# Altium schematic sheet [02] - IntelliModule Connector.SchDoc("[02] - IntelliModule Connector")
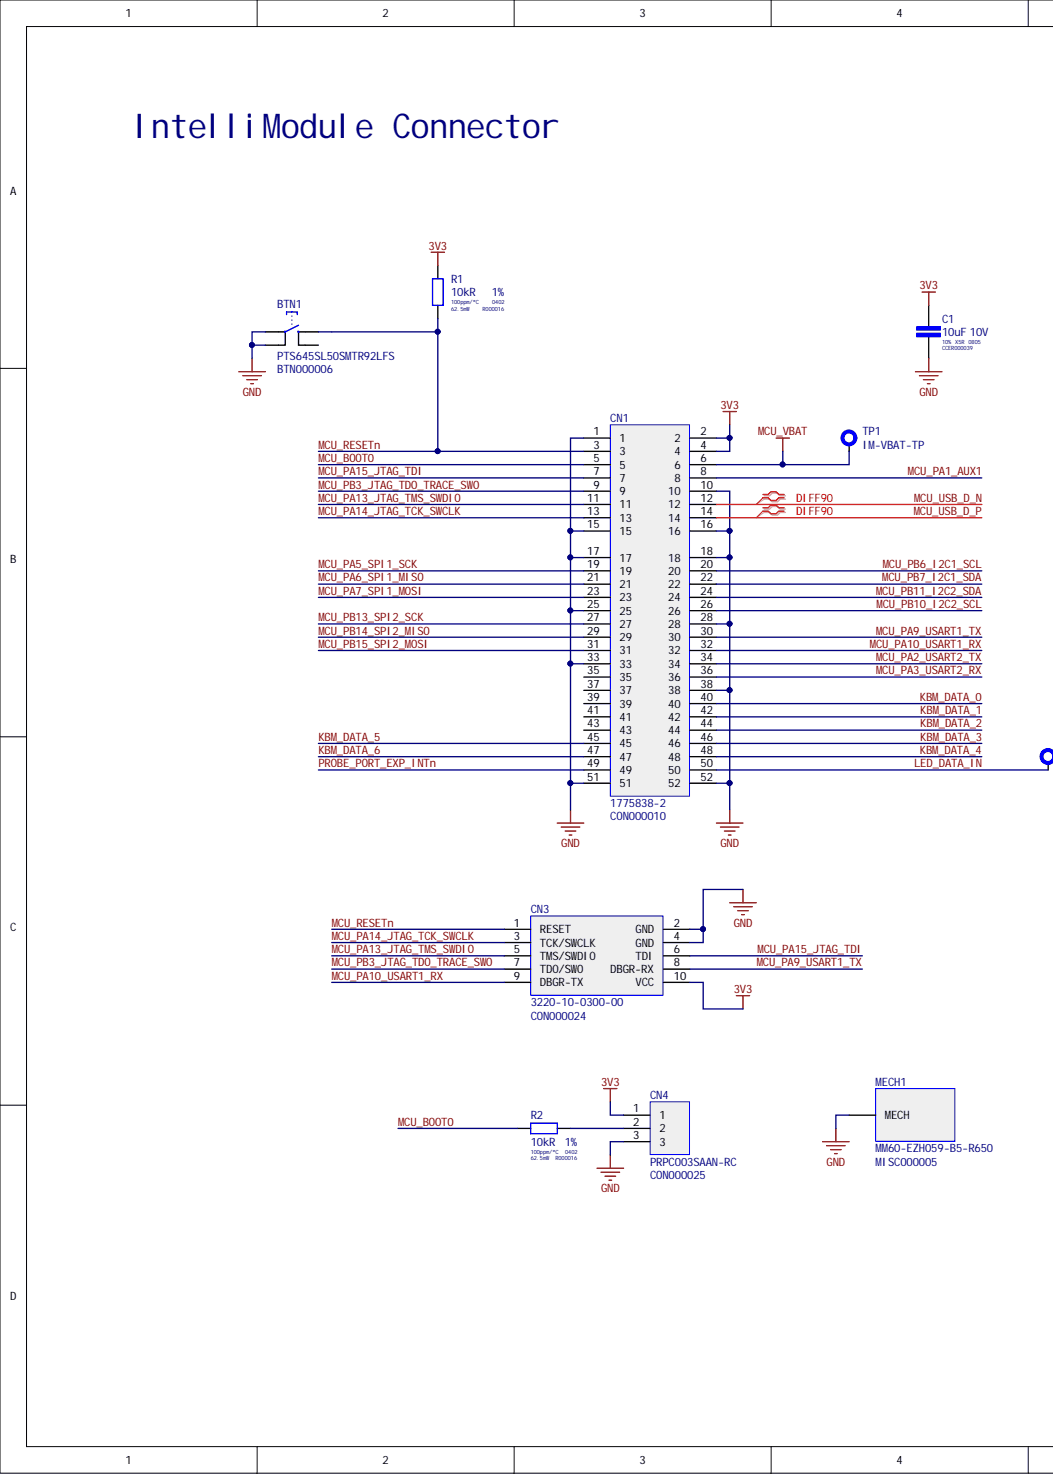
[diagram p0: [02] - IntelliModule Connector.SchDoc, left]
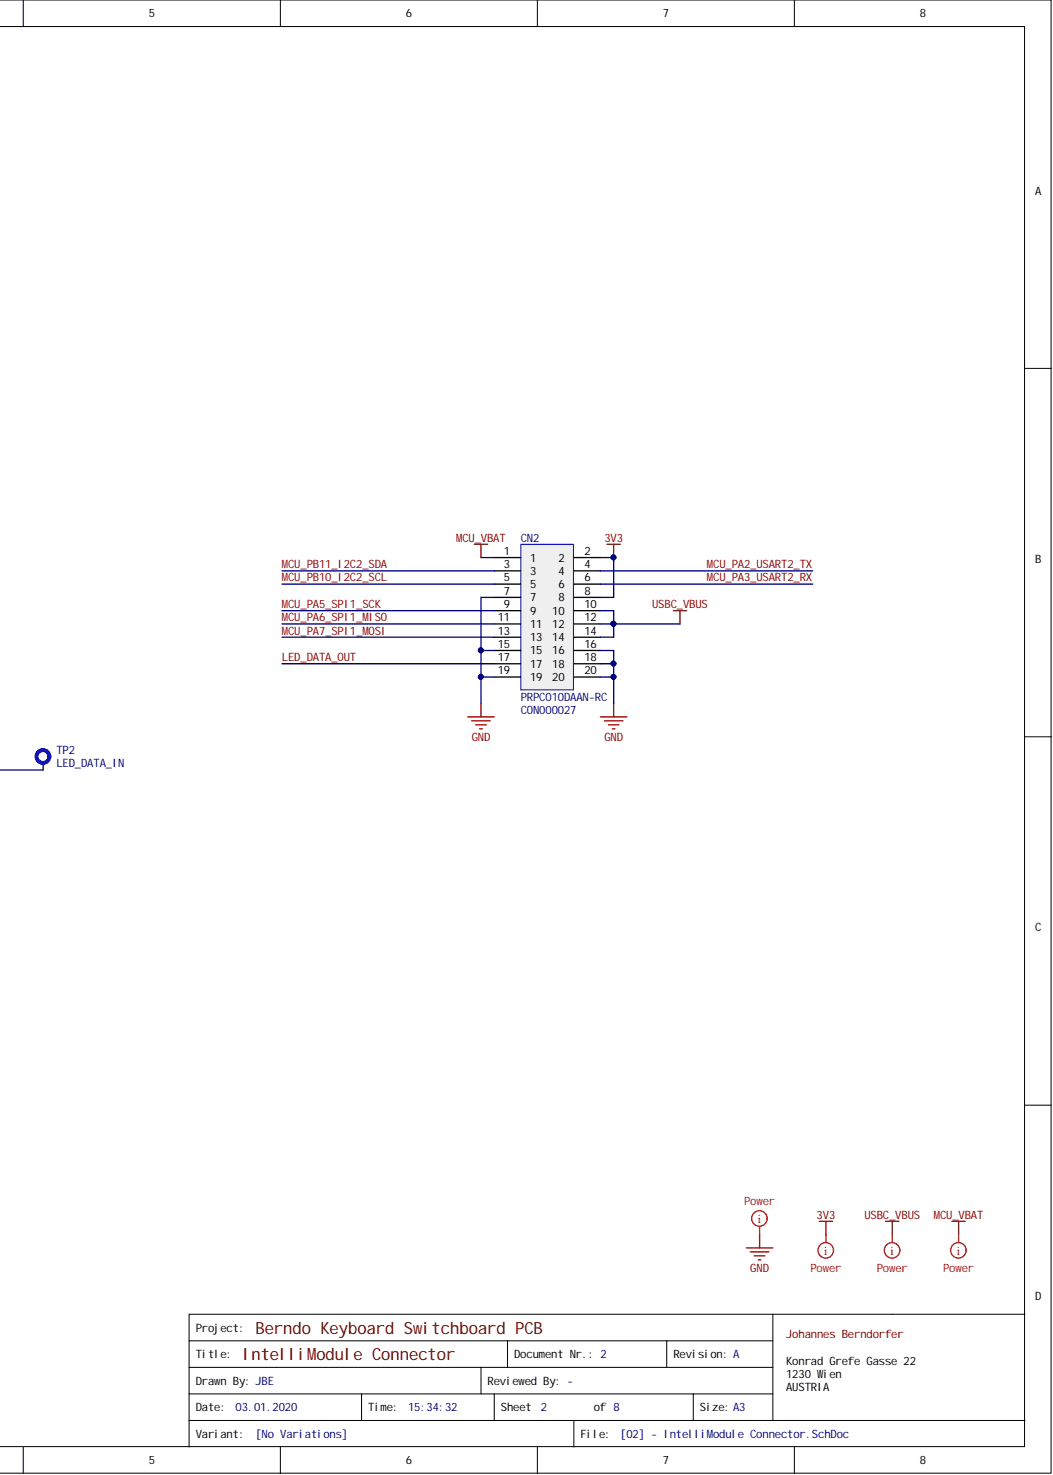
[diagram p1: [02] - IntelliModule Connector.SchDoc, right]

## Parts
BTN1  PTS645SL50SMTR92LFS  Internal Part Number: BTN000006  [BTN-SMD-PTS645-CK]
C1  10uF 10V  Voltage Rating: 10V  Tolerance: 10%  Internal Part Number: CCER000039  Dielectric: X5R  Case Code (Imperial): 0805  Capacitance: 10uF  [CAP-SMD-0805-1.35MM-IPC-MED]
CN1  1775838-2  [CON-SMD-PCIE-MINI-52POS-1775838-2-TE]
CN2  PRPC010DAAN-RC  [CON-THT-PINHEADER-2.54MM-20POS-2X10]
CN3  3220-10-0300-00  [CON-SMD-PINHEADER-SHIELDED-1.27MM-10POS-2X5-3220-10-0300-00-CNC-TECH]
CN4  PRPC003SAAN-RC  [CON-THT-PINHEADER-2.54MM-3POS-1X3]
MECH1  MM60-EZH059-B5-R650  Internal Part Number: MISC000005  [CON-SMD-PCIE-MINI-LATCH-0POS-MM60-EZH059-B5-R650-JAE]
R1  Temperature Coefficient: 100ppm/°C  Resistance: 10kR  Power Rating: 62.5mW  [RES-SMD-0402-0.4MM-IPC-MED]  (hidden comment: 10kR 1%)
R2  10kR 1%  Tolerance: 1%  Temperature Coefficient: 100ppm/°C  Resistance: 10kR  Power Rating: 62.5mW  Internal Part Number: R000016  Case Code (Imperial): 0402  [RES-SMD-0402-0.4MM-IPC-MED]
TP1  IM-VBAT-TP  [TP-SMD-CIRCULAR-1MM]
TP2  LED_DATA_IN  [TP-SMD-CIRCULAR-1MM]

## Nets
3V3: C1.1 CN1.2 CN1.4 CN2.2 CN2.8 CN3.10(VCC) CN4.1 R1.1
GND: BTN1.1 BTN1.2 C1.2 CN1.1 CN1.10 CN1.15 CN1.16 CN1.17 CN1.18 CN1.25 CN1.28 CN1.33 CN1.38 CN1.51 CN1.52 CN2.7 CN2.15 CN2.16 CN2.18 CN2.19 CN2.20 CN3.2(GND) CN3.4(GND) CN4.3 MECH1.0
MCU_VBAT: CN1.6 CN2.1 TP1.1
USBC_VBUS: CN2.10 CN2.12 CN2.14
KBM_DATA_0: CN1.40
KBM_DATA_1: CN1.42
KBM_DATA_2: CN1.44
KBM_DATA_3: CN1.46
KBM_DATA_4: CN1.48
KBM_DATA_5: CN1.45
KBM_DATA_6: CN1.47
LED_DATA_IN: CN1.50 TP2.1
LED_DATA_OUT: CN2.17
MCU_BOOT0: CN1.5 R2.1
MCU_PA1_AUX1: CN1.8
MCU_PA2_USART2_TX: CN1.34 CN2.4
MCU_PA3_USART2_RX: CN1.36 CN2.6
MCU_PA5_SPI1_SCK: CN1.19 CN2.9
MCU_PA6_SPI1_MISO: CN1.21 CN2.11
MCU_PA7_SPI1_MOSI: CN1.23 CN2.13
MCU_PA9_USART1_TX: CN1.30 CN3.8(DBGR-RX)
MCU_PA10_USART1_RX: CN1.32 CN3.9(DBGR-TX)
MCU_PA13_JTAG_TMS_SWDIO: CN1.11 CN3.5(TMS/SWDIO)
MCU_PA14_JTAG_TCK_SWCLK: CN1.13 CN3.3(TCK/SWCLK)
MCU_PA15_JTAG_TDI: CN1.7 CN3.6(TDI)
MCU_PB3_JTAG_TDO_TRACE_SWO: CN1.9 CN3.7(TDO/SWO)
MCU_PB6_I2C1_SCL: CN1.20
MCU_PB7_I2C1_SDA: CN1.22
MCU_PB10_I2C2_SCL: CN1.26 CN2.5
MCU_PB11_I2C2_SDA: CN1.24 CN2.3
MCU_PB13_SPI2_SCK: CN1.27
MCU_PB14_SPI2_MISO: CN1.29
MCU_PB15_SPI2_MOSI: CN1.31
MCU_RESETn: BTN1.3 CN1.3 CN3.1(RESET) R1.2
MCU_USB_D_N: CN1.12
MCU_USB_D_P: CN1.14
PROBE_PORT_EXP_INTn: CN1.49
NetCN4_2: CN4.2 R2.2

Not connected: BTN1 4; CN1 35 37 39 41 43

## Library data of the parts
BTN1 = PTS645SL50SMTR92LFS: BTN TACT SPST-NO 12V 50mA SMD BLACK; Manufacturer: ITT C&K; Throw Configuration: SPST; Switch Function: Off-Mom; Operating Force: 1.27N; Mechanical Life: 100000Cycles; Illumination Type: Non-Illuminated; Actuator Type: Standard; Actuator Material: Nylon,Polyamide; Voltage Rating (DC): 12V; Termination: Gull Wing; Supplier Part Number 1: CKN9088CT-ND; Supplier 1: Digi-Key; Stock 1: 127172; RoHS: Yes; REACH SVHC: No SVHC; Pricing 1: 1 = 0,31000, 10 = 0,30000, 25 = 0,29000, 50 = 0,27960, 100 = 0,26410, 250 = 0,24860, 500 = 0,23822 (USD); Packaging: Tape and Reel; Package Quantity: 1000; Orientation: Straight; Mount: Surface Mount; Min Operating Temperature: -20°C; Max Voltage Rating (DC): 12V; Max Operating Temperature: 60°C; Max Current Rating: 50mA; Manufacturer Part Number: PTS645SL50SMTR92LFS; Library Name: Altium_Lib_Standard.IntLib; Length: 6mm; Lead Free: Lead Free; Depth: 9mm; Current Rating: 50mA; Contact Plating: Silver; Contact Material: Bronze; ComponentLink6URL: https://datasheet.ciiva.com/46429/1839862-46429338.pdf?src-supplier=Element14; ComponentLink6Description: https://datasheet.ciiva.com/46429/1839862-46429338.pdf?src-supplier=Element14; ComponentLink5URL: https://datasheet.ciiva.com/46429/1839862-46429337.pdf?src-supplier=Element14; ComponentLink5Description: https://datasheet.ciiva.com/46429/1839862-46429337.pdf?src-supplier=Element14; ComponentLink4URL: https://datasheet.ciiva.com/14920/pts645-14920744.pdf?src-supplier=Digi-Key; ComponentLink4Description: https://datasheet.ciiva.com/14920/pts645-14920744.pdf?src-supplier=Digi-Key; ComponentLink3URL: https://datasheet.ciiva.com/14920/pts645-14920743.pdf?src-supplier=Digi-Key; ComponentLink3Description: https://datasheet.ciiva.com/14920/pts645-14920743.pdf?src-supplier=Digi-Key; ComponentLink2URL: https://datasheet.ciiva.com/14920/pts645-14920742.pdf?src-supplier=Digi-Key; ComponentLink2Description: https://datasheet.ciiva.com/14920/pts645-14920742.pdf?src-supplier=Digi-Key; ComponentLink1URL: https://datasheet.ciiva.com/26927/getdatasheetpartid-760859-26927133.pdf?src-supplier=Verical; ComponentLink1Description: https://datasheet.ciiva.com/26927/getdatasheetpartid-760859-26927133.pdf?src-supplier=Verical; Component Kind: Standard; Color: Black
C1 = CL21A106KPFNNNG: CAP CER 10U 10V X5R 10% SMD 0805 85C SAMSUNG; Width: 0.049inch; Voltage: 10V; Thickness: 0.053inch; Termination: Wraparound; Supplier Part Number 1: 1276-6456-1-ND; Supplier 1: Digi-Key; Stock 1: 884042; RoHS: Yes; Radiation Hardening: No; Pricing 1: 1=0,14000, 10=0,10000, 100=0,04690, 500=0,03290, 1000=0,02724 (USD); Packaging: Tape and Reel; Package Quantity: 3000; Mount: Surface Mount; Min Operating Temperature: -55°C; Max Operating Temperature: 85°C; Manufacturer Part Number 1: CL21A106KPFNNNG; Manufacturer 1: Samsung; Library Name: Altium_Lib_Standard.IntLib; Length: 2mm; Lead Free: Lead Free; Height: 1.25mm; Depth: 1.25mm; ComponentLink2URL: https://datasheet.ciiva.com/26936/getdatasheetpartid-640497-26936900.pdf?src-supplier=Verical; ComponentLink2Description: https://datasheet.ciiva.com/26936/getdatasheetpartid-640497-26936900.pdf?src-supplier=Verical; ComponentLink1URL: https://datasheet.ciiva.com/16428/cl21a106kpfnnng-16428896.pdf?src-supplier=Future+Electronics; ComponentLink1Description: https://datasheet.ciiva.com/16428/cl21a106kpfnnng-16428896.pdf?src-supplier=Future+Electronics; Component Kind: Standard; Case Code (Metric): 2012; Case/Package: 0805
CN1 = 1775838-2: CON MINI PCIE CARD EDGE 52POS SMD; Manufacturer 1: TE Connectivity AMP; Internal Part Number: CON000010; Stack Height: 5.6mm; Stackable: No; Row Spacing: 0.031inch; Pitch: 0.8mm; PCB Mount Retention: Without; PCB Mounting Orientation: Vertical; Number of Positions: 52; High Temperature Housing: Yes; Connector Type: Receptacle; Termination: Solder; Supplier Part Number 1: A123340CT-ND; Supplier 1: Digi-Key; Stock 1: 12692; RoHS: Yes; Pricing 1: 1=0,76000, 10=0,67100, 25=0,63160, 50=0,60520, 100=0,57880, 250=0,52620 (USD); Packaging: Tape and Reel; Package Quantity: 500; Orientation: Right Angle; Number of Rows: 2; Mount: Surface Mount; Manufacturer Part Number 1: 1775838-2; Library Name: Altium_Lib_Standard.IntLib; Lead Free: Lead Free; Housing Material: Thermoplastic; Housing Color: Black; Flammability Rating: UL94 V-0; ELV: Compliant; Contact Plating: Gold; Contact Material: Copper Alloy; ComponentLink3URL: https://datasheet.ciiva.com/10924/eng-ds-8-1773459-7-express-mini-card-qrg-1011-10924539.pdf?src-supplier=Avnet; ComponentLink3Description: https://datasheet.ciiva.com/10924/eng-ds-8-1773459-7-express-mini-card-qrg-1011-10924539.pdf?src-supplier=Avnet; ComponentLink2URL: https://datasheet.ciiva.com/48777/eng-ds-8-1773459-7-express-mini-card-qrg-1011-48777258.pdf?src-supplier=Onlinecomponents.com; ComponentLink2Description: https://datasheet.ciiva.com/48777/eng-ds-8-1773459-7-express-mini-card-qrg-1011-48777258.pdf?src-supplier=Onlinecomponents.com; ComponentLink1URL: https://datasheet.ciiva.com/16468/eng-ds-8-1773459-7-express-mini-card-qrg-1011-16468375.pdf?src-supplier=Avnet+Asia; ComponentLink1Description: https://datasheet.ciiva.com/16468/eng-ds-8-1773459-7-express-mini-card-qrg-1011-16468375.pdf?src-supplier=Avnet+Asia; Component Kind: Standard
CN2 = PRPC010DAAN-RC: CON PINHDR 2.54MM 2x10; Manufacturer: Sullins; Internal Part Number: CON000027; Row Spacing: 0.1inch; Number of Positions: 20; Connector Type: Male Pin; Termination: Solder; Supplier Part Number 1: S2011EC-10-ND; Supplier 1: Digi-Key; Stock 1: 2248; RoHS: Yes; Pricing 1: 1 = 0,50000, 10 = 0,46400, 25 = 0,41800, 50 = 0,37160, 100 = 0,35600, 250 = 0,32508, 500 = 0,30960 (USD); Pitch: 0.1inch; Packaging: Bulk; Package Quantity: 1; Number of Rows: 2; Mount: Through Hole; Manufacturer Part Number: PRPC010DAAN-RC; Library Name: Altium_Lib_Standard.IntLib; Contact Plating: Gold; Contact Mating Length: 0.23inch; ComponentLink1URL: https://datasheet.ciiva.com/741/xrxczzzdxxn-rc-11636-b-741275.pdf?src-supplier=Digi-Key; ComponentLink1Description: https://datasheet.ciiva.com/741/xrxczzzdxxn-rc-11636-b-741275.pdf?src-supplier=Digi-Key; Component Kind: Standard; Color: Black
CN3 = 3220-10-0300-00: CON PINHDR SMD 1.27MM SHIELDED KEYED CNC TECH 10POS; Internal Part Number: CON000024; Row Spacing: 0.05inch; Number of Positions: 10; Connector Type: Male Pin; Termination: Solder; Supplier Part Number 1: 1175-1629-ND; Supplier 1: Digi-Key; Stock 1: 107713; RoHS: Yes; Pricing 1: 1=0,74000, 10=0,69000, 100=0,52900, 500=0,46000, 3200=0,34500, 6400=0,33350, 12800=0,30590 (USD); Pitch: 0.05inch; Packaging: Tray; Package Quantity: 3200; Number of Rows: 2; Mount: Surface Mount; Manufacturer Part Number 1: 3220-10-0300-00; Manufacturer 1: CNC Tech; Library Name: Altium_Lib_Standard.IntLib; Contact Plating: Gold; ComponentLink1URL: https://datasheet.ciiva.com/18655/3220-xx-0300-00-18655365.pdf?src-supplier=Digi-Key; ComponentLink1Description: https://datasheet.ciiva.com/18655/3220-xx-0300-00-18655365.pdf?src-supplier=Digi-Key; Component Kind: Standard
CN4 = PRPC003SAAN-RC: CON PINHDR 2.54MM 1x03; Manufacturer: Sullins; Internal Part Number: CON000025; Pitch: 0.1inch; Number of Positions: 3; Connector Type: Male Pin; Termination: Solder; Supplier Part Number 1: S1011EC-03-ND; Supplier 1: Digi-Key; Stock 1: 16923; RoHS: Yes; Pricing 1: 1 = 0,12000, 10 = 0,10900, 25 = 0,08120, 50 = 0,06960, 100 = 0,06730, 250 = 0,05804, 500 = 0,05572 (USD); Packaging: Bulk; Package Quantity: 1; Number of Rows: 1; Mount: Through Hole; Manufacturer Part Number: PRPC003SAAN-RC; Library Name: Altium_Lib_Standard.IntLib; Contact Plating: Gold; Contact Mating Length: 0.23inch; ComponentLink1URL: https://datasheet.ciiva.com/741/xrxczzzsxxn-rc-st-11635-b-741242.pdf?src-supplier=Digi-Key; ComponentLink1Description: https://datasheet.ciiva.com/741/xrxczzzsxxn-rc-st-11635-b-741242.pdf?src-supplier=Digi-Key; Component Kind: Standard; Color: Black
MECH1 = MM60-EZH059-B5-R650: CARD LATCH MINI PCIE 3.05mm HEIGHT; Manufacturer: JAE Electronics; Mating Cycles: 50; Current Rating: 500mA; Contact Resistance: 55mR; Termination: Solder; Supplier Part Number 1: 670-2277-1-ND; Supplier 1: Digi-Key; Stock 1: 14566; RoHS: Yes; REACH SVHC: Unknown; Pricing 1: 1 = 1,22000, 10 = 1,07500, 25 = 1,01160, 50 = 0,96940, 100 = 0,92720, 250 = 0,84296 (USD); Packaging: Tape and Reel; Package Quantity: 1; Mount: Socket; Min Operating Temperature: -40°C; Max Operating Temperature: 80°C; Manufacturer Part Number: MM60-EZH059-B5-R650; Library Name: Altium_Lib_Standard.IntLib; Height: 5.9mm; Flammability Rating: UL94 V-0; Contact Plating: Tin; Contact Material: Stainless Steel,Tin; ComponentLink2URL: https://datasheet.ciiva.com/7655/332202-7655815.pdf?src-supplier=Element14; ComponentLink2Description: https://datasheet.ciiva.com/7655/332202-7655815.pdf?src-supplier=Element14; ComponentLink1URL: https://datasheet.ciiva.com/682/sj105221-682461.pdf?src-supplier=Digi-Key; ComponentLink1Description: https://datasheet.ciiva.com/682/sj105221-682461.pdf?src-supplier=Digi-Key; Component Kind: Standard; Case/Package: SMD/SMT
R1 = RC0402FR-0710KL: RES 10K 1% 1/16W SMD 0402 YAEGO; Manufacturer: Yageo; Internal Part Number: R000016; Working Voltage: 50V; Width: 0.5mm; Voltage Rating (DC): 50V; Voltage Rating: 50V; Tolerance: 1%; Termination: SMD/SMT; Supplier Part Number 1: 311-10.0KLRCT-ND; Supplier 1: Digi-Key; Stock 1: 86154015; RoHS: Yes; REACH SVHC: No SVHC; Pricing 1: 1 = 0,10000, 10 = 0,01600, 100 = 0,00670, 1000 = 0,00301, 2500 = 0,00261, 5000 = 0,00215 (USD); Packaging: Tape and Reel; Package Quantity: 10000; Number of Terminations: 2; Number of Pins: 2; Min Operating Temperature: -55°C; Military Standard: Not; Max Operating Temperature: 155°C; Manufacturer Part Number: RC0402FR-0710KL; Library Name: Altium_Lib_Standard.IntLib; Length: 1mm; Lead Pitch: 0.2mm; Lead Free: Lead Free; Height: 0.32mm; Depth: 0.5mm; Composition: Thick Film; ComponentLink13URL: https://datasheet.ciiva.com/17070/pyu-rc0402-51-rohs-l-6-r-17070335.pdf?src-supplier=Future+Electronics; ComponentLink13Description: https://datasheet.ciiva.com/17070/pyu-rc0402-51-rohs-l-6-r-17070335.pdf?src-supplier=Future+Electronics; ComponentLink12URL: https://datasheet.ciiva.com/7849/1018594-7849967.pdf?src-supplier=Element14; ComponentLink12Description: https://datasheet.ciiva.com/7849/1018594-7849967.pdf?src-supplier=Element14; ComponentLink11URL: https://datasheet.ciiva.com/7849/1018594-7849966.pdf?src-supplier=Element14; ComponentLink11Description: https://datasheet.ciiva.com/7849/1018594-7849966.pdf?src-supplier=Element14; ComponentLink10URL: https://datasheet.ciiva.com/24070/pyu-rc0402-51-rohs-l-6-r-8721-24070077.pdf?src-supplier=Mouser; ComponentLink10Description: https://datasheet.ciiva.com/24070/pyu-rc0402-51-rohs-l-6-r-8721-24070077.pdf?src-supplier=Mouser; ComponentLink9URL: https://datasheet.ciiva.com/15189/getdatasheetpartid-329253-15189431.pdf?src-supplier=Verical; ComponentLink9Description: https://datasheet.ciiva.com/15189/getdatasheetpartid-329253-15189431.pdf?src-supplier=Verical; ComponentLink8URL: https://datasheet.ciiva.com/11819/0900766b80c66297-11819487.pdf?src-supplier=RSComponents; ComponentLink8Description: https://datasheet.ciiva.com/11819/0900766b80c66297-11819487.pdf?src-supplier=RSComponents; ComponentLink7URL: https://datasheet.ciiva.com/7983/1018594-7983486.pdf?src-supplier=Element14; ComponentLink7Description: https://datasheet.ciiva.com/7983/1018594-7983486.pdf?src-supplier=Element14; ComponentLink6URL: https://datasheet.ciiva.com/12667/pyu-rc0402-51-rohs-l-6-r-12667067.pdf?src-supplier=Mouser; ComponentLink6Description: https://datasheet.ciiva.com/12667/pyu-rc0402-51-rohs-l-6-r-12667067.pdf?src-supplier=Mouser; ComponentLink5URL: https://datasheet.ciiva.com/22747/1018594-22747512.pdf?src-supplier=Element14; ComponentLink5Description: https://datasheet.ciiva.com/22747/1018594-22747512.pdf?src-supplier=Element14; ComponentLink4URL: https://datasheet.ciiva.com/7849/1784430-7849815.pdf?src-supplier=Element14; ComponentLink4Description: https://datasheet.ciiva.com/7849/1784430-7849815.pdf?src-supplier=Element14; ComponentLink3URL: https://datasheet.ciiva.com/7849/1686128-7849814.pdf?src-supplier=Element14; ComponentLink3Description: https://datasheet.ciiva.com/7849/1686128-7849814.pdf?src-supplier=Element14; ComponentLink2URL: https://datasheet.ciiva.com/7849/1784430-7849813.pdf?src-supplier=Element14; ComponentLink2Description: https://datasheet.ciiva.com/7849/1784430-7849813.pdf?src-supplier=Element14; ComponentLink1URL: https://datasheet.ciiva.com/7660/38568-7660787.pdf?src-supplier=Element14; ComponentLink1Description: https://datasheet.ciiva.com/7660/38568-7660787.pdf?src-supplier=Element14; Component Kind: Standard; Case Code (Metric): 1005; Case Code (Imperial): 0402; Case/Package: 0402
R2 = RC0402FR-0710KL: RES 10K 1% 1/16W SMD 0402 YAEGO; Manufacturer: Yageo; Working Voltage: 50V; Width: 0.5mm; Voltage Rating (DC): 50V; Voltage Rating: 50V; Termination: SMD/SMT; Supplier Part Number 1: 311-10.0KLRCT-ND; Supplier 1: Digi-Key; Stock 1: 86154015; RoHS: Yes; REACH SVHC: No SVHC; Pricing 1: 1 = 0,10000, 10 = 0,01600, 100 = 0,00670, 1000 = 0,00301, 2500 = 0,00261, 5000 = 0,00215 (USD); Packaging: Tape and Reel; Package Quantity: 10000; Number of Terminations: 2; Number of Pins: 2; Min Operating Temperature: -55°C; Military Standard: Not; Max Operating Temperature: 155°C; Manufacturer Part Number: RC0402FR-0710KL; Library Name: Altium_Lib_Standard.IntLib; Length: 1mm; Lead Pitch: 0.2mm; Lead Free: Lead Free; Height: 0.32mm; Depth: 0.5mm; Composition: Thick Film; ComponentLink13URL: https://datasheet.ciiva.com/17070/pyu-rc0402-51-rohs-l-6-r-17070335.pdf?src-supplier=Future+Electronics; ComponentLink13Description: https://datasheet.ciiva.com/17070/pyu-rc0402-51-rohs-l-6-r-17070335.pdf?src-supplier=Future+Electronics; ComponentLink12URL: https://datasheet.ciiva.com/7849/1018594-7849967.pdf?src-supplier=Element14; ComponentLink12Description: https://datasheet.ciiva.com/7849/1018594-7849967.pdf?src-supplier=Element14; ComponentLink11URL: https://datasheet.ciiva.com/7849/1018594-7849966.pdf?src-supplier=Element14; ComponentLink11Description: https://datasheet.ciiva.com/7849/1018594-7849966.pdf?src-supplier=Element14; ComponentLink10URL: https://datasheet.ciiva.com/24070/pyu-rc0402-51-rohs-l-6-r-8721-24070077.pdf?src-supplier=Mouser; ComponentLink10Description: https://datasheet.ciiva.com/24070/pyu-rc0402-51-rohs-l-6-r-8721-24070077.pdf?src-supplier=Mouser; ComponentLink9URL: https://datasheet.ciiva.com/15189/getdatasheetpartid-329253-15189431.pdf?src-supplier=Verical; ComponentLink9Description: https://datasheet.ciiva.com/15189/getdatasheetpartid-329253-15189431.pdf?src-supplier=Verical; ComponentLink8URL: https://datasheet.ciiva.com/11819/0900766b80c66297-11819487.pdf?src-supplier=RSComponents; ComponentLink8Description: https://datasheet.ciiva.com/11819/0900766b80c66297-11819487.pdf?src-supplier=RSComponents; ComponentLink7URL: https://datasheet.ciiva.com/7983/1018594-7983486.pdf?src-supplier=Element14; ComponentLink7Description: https://datasheet.ciiva.com/7983/1018594-7983486.pdf?src-supplier=Element14; ComponentLink6URL: https://datasheet.ciiva.com/12667/pyu-rc0402-51-rohs-l-6-r-12667067.pdf?src-supplier=Mouser; ComponentLink6Description: https://datasheet.ciiva.com/12667/pyu-rc0402-51-rohs-l-6-r-12667067.pdf?src-supplier=Mouser; ComponentLink5URL: https://datasheet.ciiva.com/22747/1018594-22747512.pdf?src-supplier=Element14; ComponentLink5Description: https://datasheet.ciiva.com/22747/1018594-22747512.pdf?src-supplier=Element14; ComponentLink4URL: https://datasheet.ciiva.com/7849/1784430-7849815.pdf?src-supplier=Element14; ComponentLink4Description: https://datasheet.ciiva.com/7849/1784430-7849815.pdf?src-supplier=Element14; ComponentLink3URL: https://datasheet.ciiva.com/7849/1686128-7849814.pdf?src-supplier=Element14; ComponentLink3Description: https://datasheet.ciiva.com/7849/1686128-7849814.pdf?src-supplier=Element14; ComponentLink2URL: https://datasheet.ciiva.com/7849/1784430-7849813.pdf?src-supplier=Element14; ComponentLink2Description: https://datasheet.ciiva.com/7849/1784430-7849813.pdf?src-supplier=Element14; ComponentLink1URL: https://datasheet.ciiva.com/7660/38568-7660787.pdf?src-supplier=Element14; ComponentLink1Description: https://datasheet.ciiva.com/7660/38568-7660787.pdf?src-supplier=Element14; Component Kind: Standard; Case Code (Metric): 1005; Case/Package: 0402
TP1 TP2 = TESTPOINT_CIRCULAR_1MM: Library Name: Altium_Lib_Standard.IntLib; Component Kind: Standard
